# Altium schematic sheet [8]ADC_chip.SchDoc
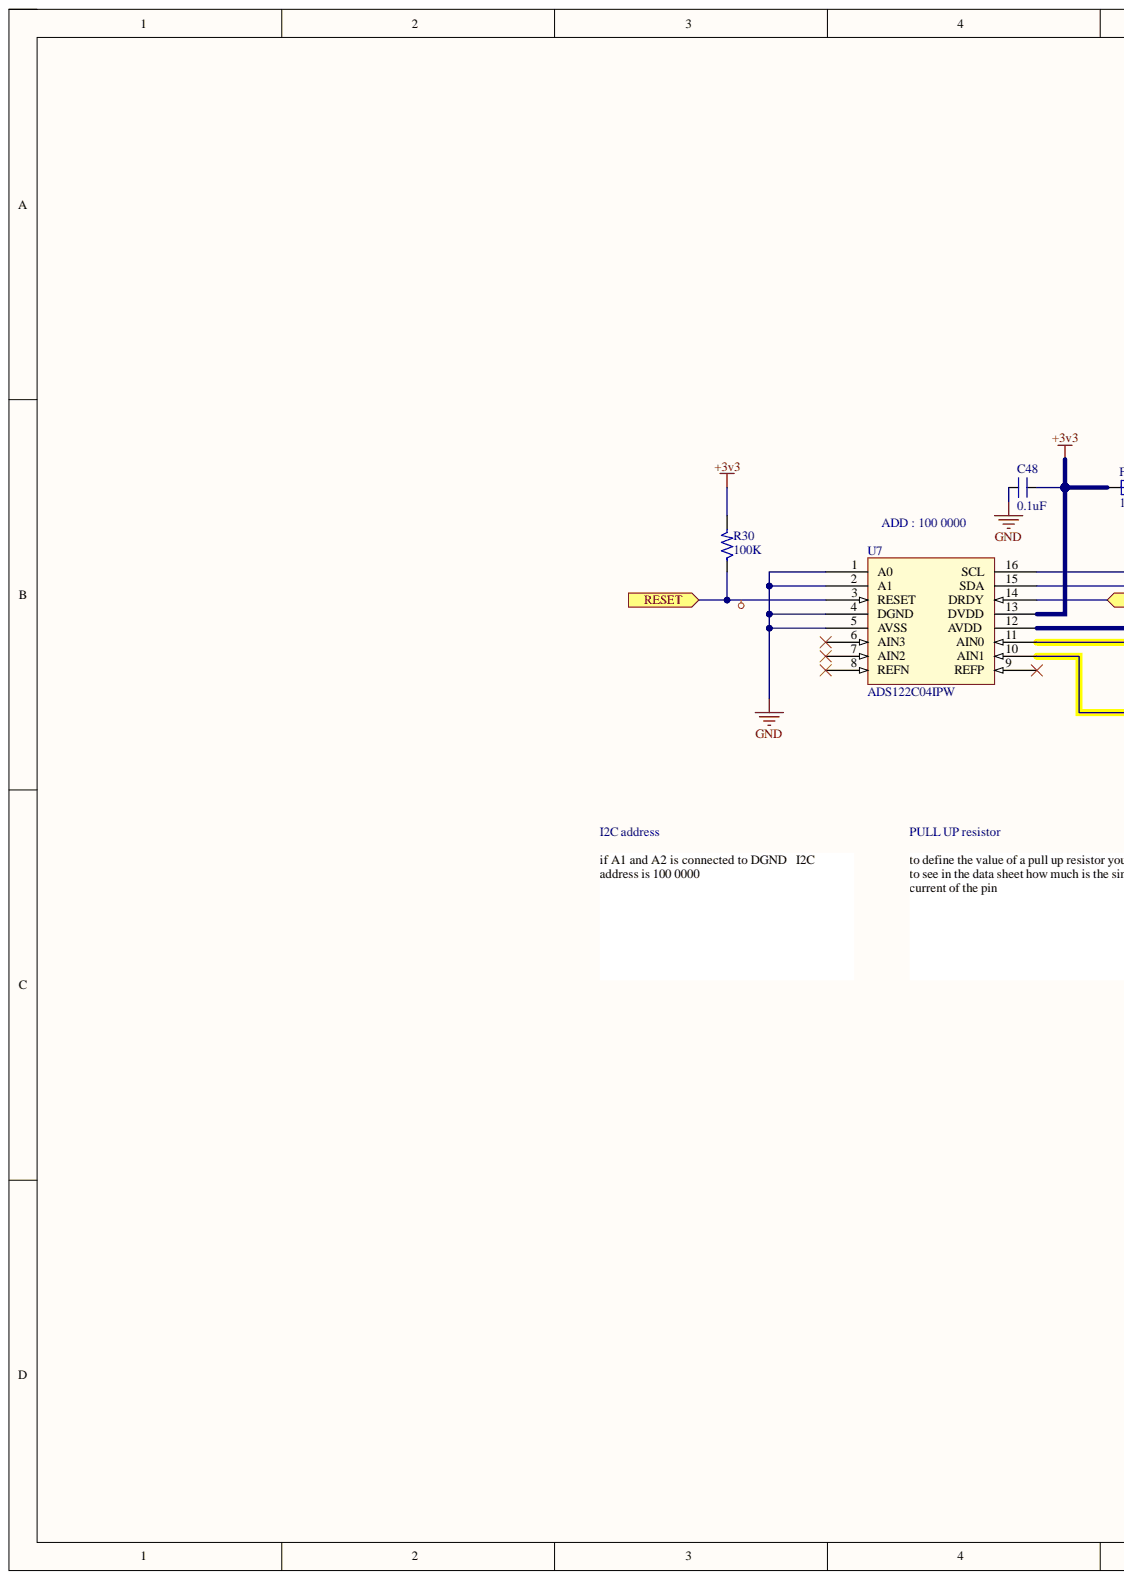
[diagram p0: [8]ADC_chip.SchDoc, left]
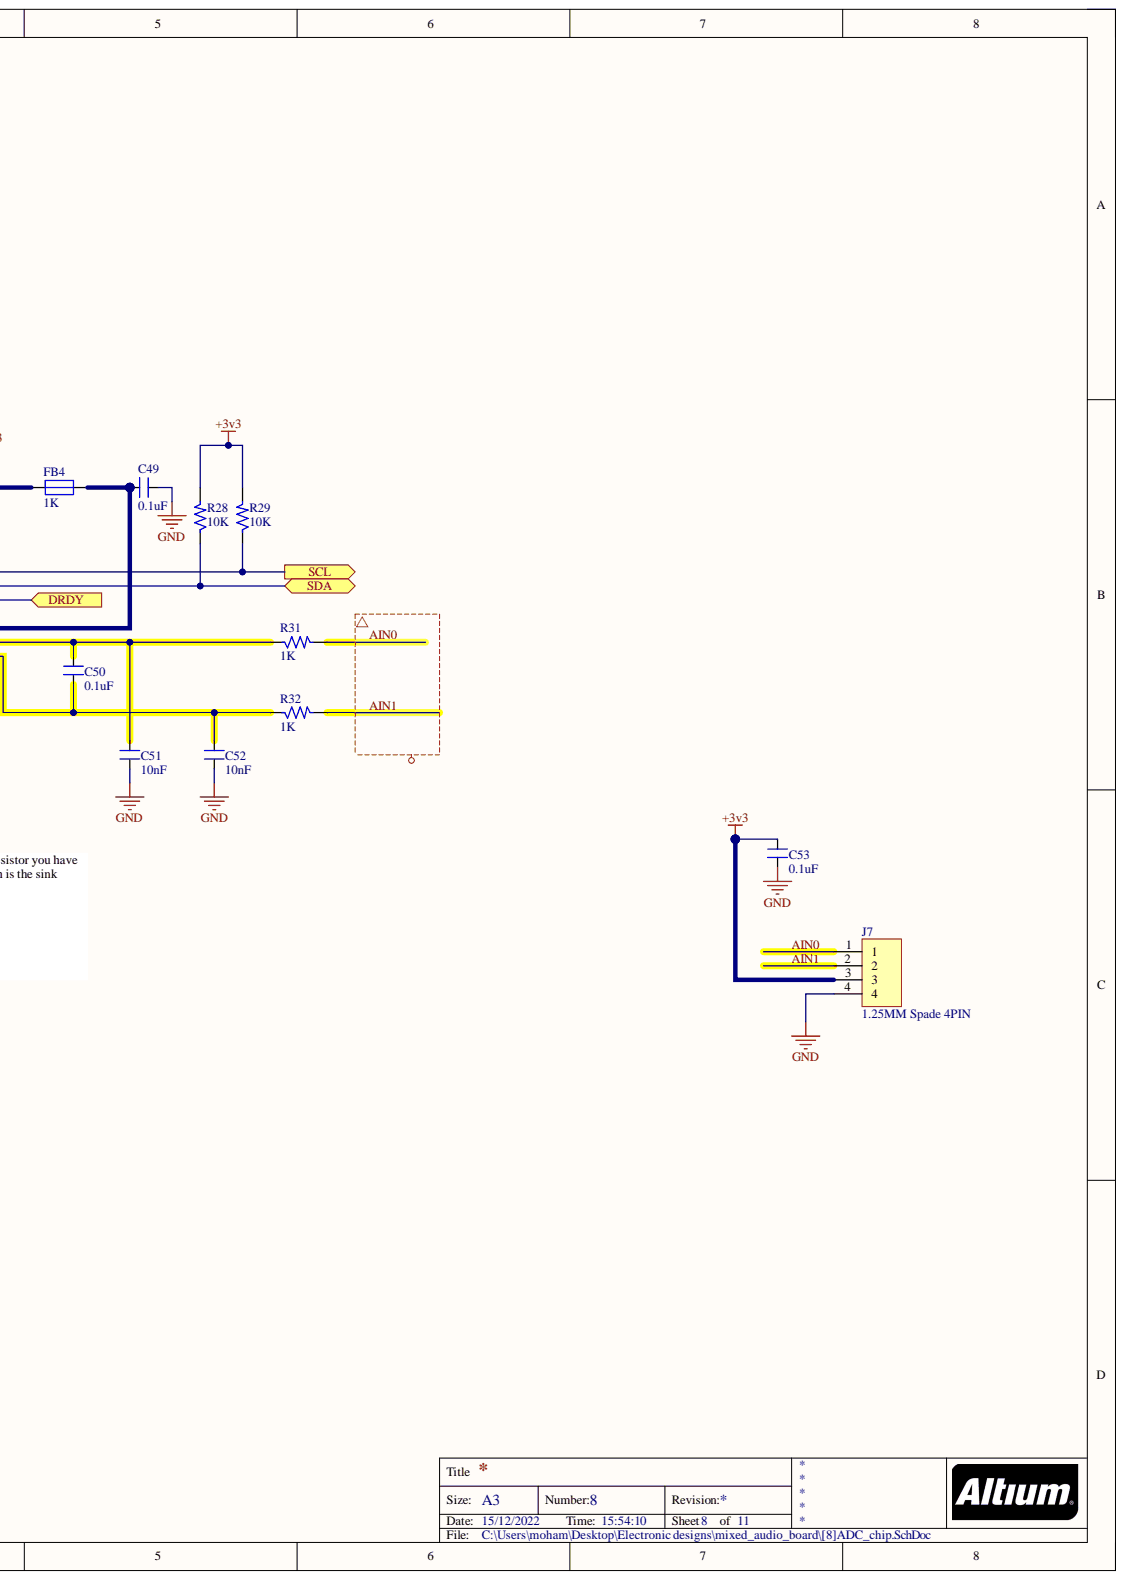
[diagram p1: [8]ADC_chip.SchDoc, right]

## Parts
C48  0.1uF  [CAP_0805]
C49  0.1uF  [CAP_0805]
C50  0.1uF  [CAP_0805]
C51  10nF  [CAP_0805]
C52  10nF  [CAP_0805]
C53  0.1uF  [CAP_0805]
FB4  1K  [IND_0805]
J7  1.25MM Spade 4PIN  [CON4_50_653004117322_TH_RA]
R28  10K  [RES_0603]
R29  10K  [RES_0603]
R30  100K  [RES_0603]
R31  1K  [RES_0603]
R32  1K  [RES_0603]
U7  ADS122C04IPW  [TSSOP16_25-59_294_54X14_SMT]

## Ports
DRDY, RESET, SCL, SDA

## Nets
+3v3: C48.2 C53.2 FB4.1 J7.3 R28.2 R29.2 R30.2 U7.13(DVDD)
GND: C48.1 C49.2 C51.1 C52.1 C53.1 J7.4 U7.1(A0) U7.2(A1) U7.4(DGND) U7.5(AVSS)
AIN0: J7.1 R31.2
AIN1: J7.2 R32.2
DRDY: U7.14(DRDY) ; port DRDY
RESET: R30.1 U7.3(RESET) ; port RESET
SCL: R29.1 U7.16(SCL) ; port SCL
SDA: R28.1 U7.15(SDA) ; port SDA
NetC49_1: C49.1 FB4.2 U7.12(AVDD)
NetC50_1: C50.1 C52.2 R32.1 U7.10(AIN1)
NetC50_2: C50.2 C51.2 R31.1 U7.11(AIN0)

Not connected: U7 6(AIN3) 7(AIN2) 8(REFN) 9(REFP)

## Library data of the parts
C48-C50 C53 = CAP_0.1uF-50V-5%-0805: 0.1µF ±5% 50V Ceramic Capacitor X7R 0805 (2012 Metric); Alternate Part Number-1: 0805B104M500; Manufacturer: AVX Corporation; Manufacturer Part Number: 08055C104JAT4A; Case/Package: 0805; Component Kind: Standard; Library Name: ESTEEMPCBLIB.IntLib; Supplier Part Number-1: 0805B104J500CT; Supplier-1: SIRICOM
C51 C52 = CAP_10nF-50V-10%-0805: 10000pF ±10% 50V Ceramic Capacitor X7R 0805 (2012 Metric); Alternate Part Number-1: GRM2195C1H103JA01D; Manufacturer: Samsung; Manufacturer Part Number: C0805C103K5RECAUTO; Case/Package: 0805; Component Kind: Standard; Library Name: ESTEEMPCBLIB.IntLib; Supplier Part Number-1: 0805B103K500CT; Supplier-1: SIRICOM
FB4 = FB_1K-100MHZ-1.6A-0805: 1 kOhms @ 100MHz 1 Power Line Ferrite Bead 0805 (2012 Metric) 1.6A; Alternate Part Number-1: NA; Manufacturer: Laird; Manufacturer Part Number: BLM21SP102SH1D; Case/Package: 0805; Component Kind: Standard; Library Name: ESTEEMPCBLIB.IntLib; Supplier Part Number-1: ACMS201209A102; Supplier-1: SIRICOM
J7 = CON-PLG_4X1-50-RA-TH: 4 Pins, 1 Row 1.25mm Pitch, right angle Connector, male; Alternate Part Number-1: NA; Crimp Part Number: 653153128130; Manufacturer: JST; Manufacturer Part Number: 653004117322; Mating Part Number: 653004113322; Case/Package: TH-CON; Component Kind: Standard; Library Name: ESTEEMPCBLIB.IntLib; Supplier Part Number-1: 653004117322; Supplier-1: WURTH
R28 R29 = RES_10K-0.1W-1%-0603: 10 kOhms ±1% 0.1W, 1/10W Chip Resistor 0603 (1608 Metric) Automotive AEC-Q200 Thick Film; Alternate Part Number-1: 0603WAJ0103T5E; Manufacturer: Walsin; Manufacturer Part Number: WR06X1002FTL; Case/Package: 0603; Component Kind: Standard; Library Name: ESTEEMPCBLIB.IntLib; Supplier Part Number-1: WR06X1002FTL; Supplier-1: SIRICOM
R30 = RES_100K-0.1W-1%-0603: 100 kOhms ±1% 0.1W, 1/10W Chip Resistor 0603 (1608 Metric) Automotive AEC-Q200 Thick Film; Alternate Part Number-1: NA; Manufacturer: Stackpole Electronics; Manufacturer Part Number: RMCF0603FT100K; Case/Package: 0603; Component Kind: Standard; Library Name: ESTEEMPCBLIB.IntLib; Supplier Part Number-1: RMCF0603FT100K; Supplier-1: Arrow
R31 R32 = RES_1K-0.1W-1%-0603: 1 kOhms ±1% 0.1W, 1/10W Chip Resistor 0603 (1608 Metric) Automotive AEC-Q200 Thick Film; Alternate Part Number-1: 0603WAF1001T5E; Manufacturer: Walsin; Manufacturer Part Number: WR06X1001FTL; Case/Package: 0603; Component Kind: Standard; Library Name: ESTEEMPCBLIB.IntLib; Supplier Part Number-1: WR06X1001FTL; Supplier-1: SIRICOM
U7 = IC_ADS122C04IPW: 24 Bit Analog to Digital Converter 4 Input 1 Sigma-Delta 16-TSSOP; Alternate Part Number-1: NA; Manufacturer: Texas Instruments; Case/Package: TSSOP16; Component Kind: Standard; Library Name: ESTEEMPCBLIB.IntLib; Manufacturer Part Number: ADS122C04IPW; Supplier Part Number-1: ADS122C04IPW; Supplier-1: MOUSER
